# Altium schematic sheet [14] - FPGA POWER.SchDoc("[14] - FPGA POWER")
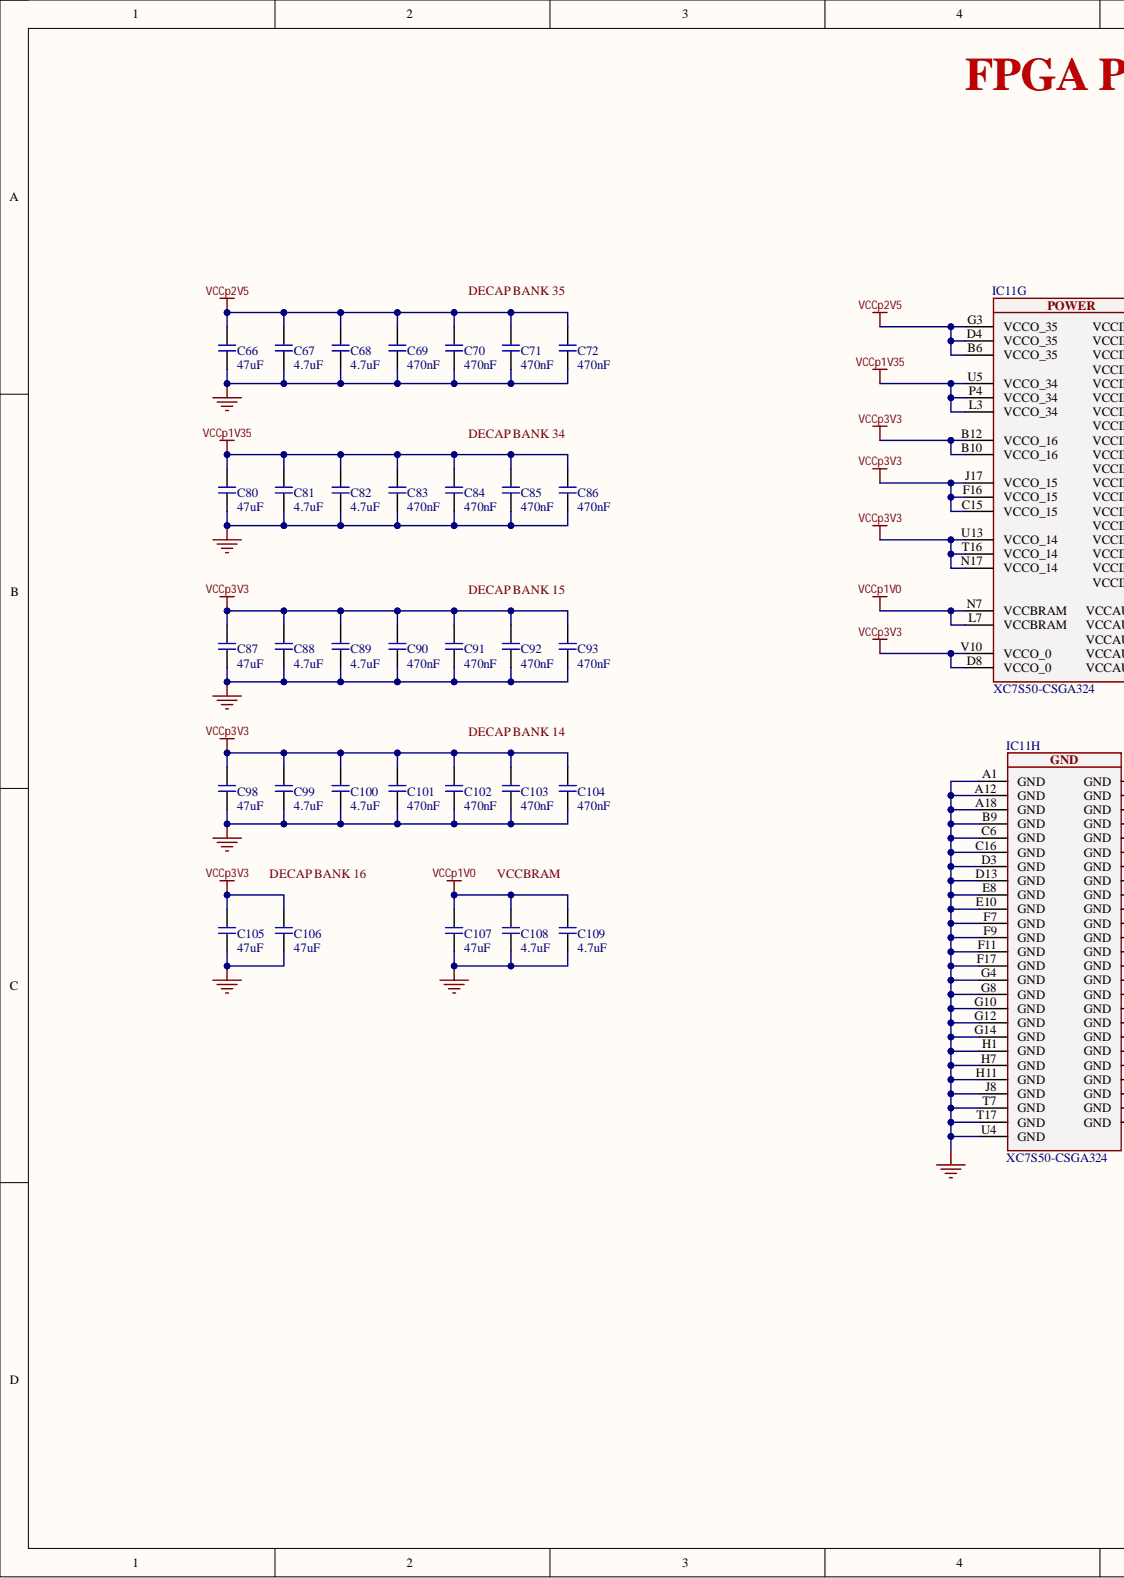
[diagram p0: [14] - FPGA POWER.SchDoc, left]
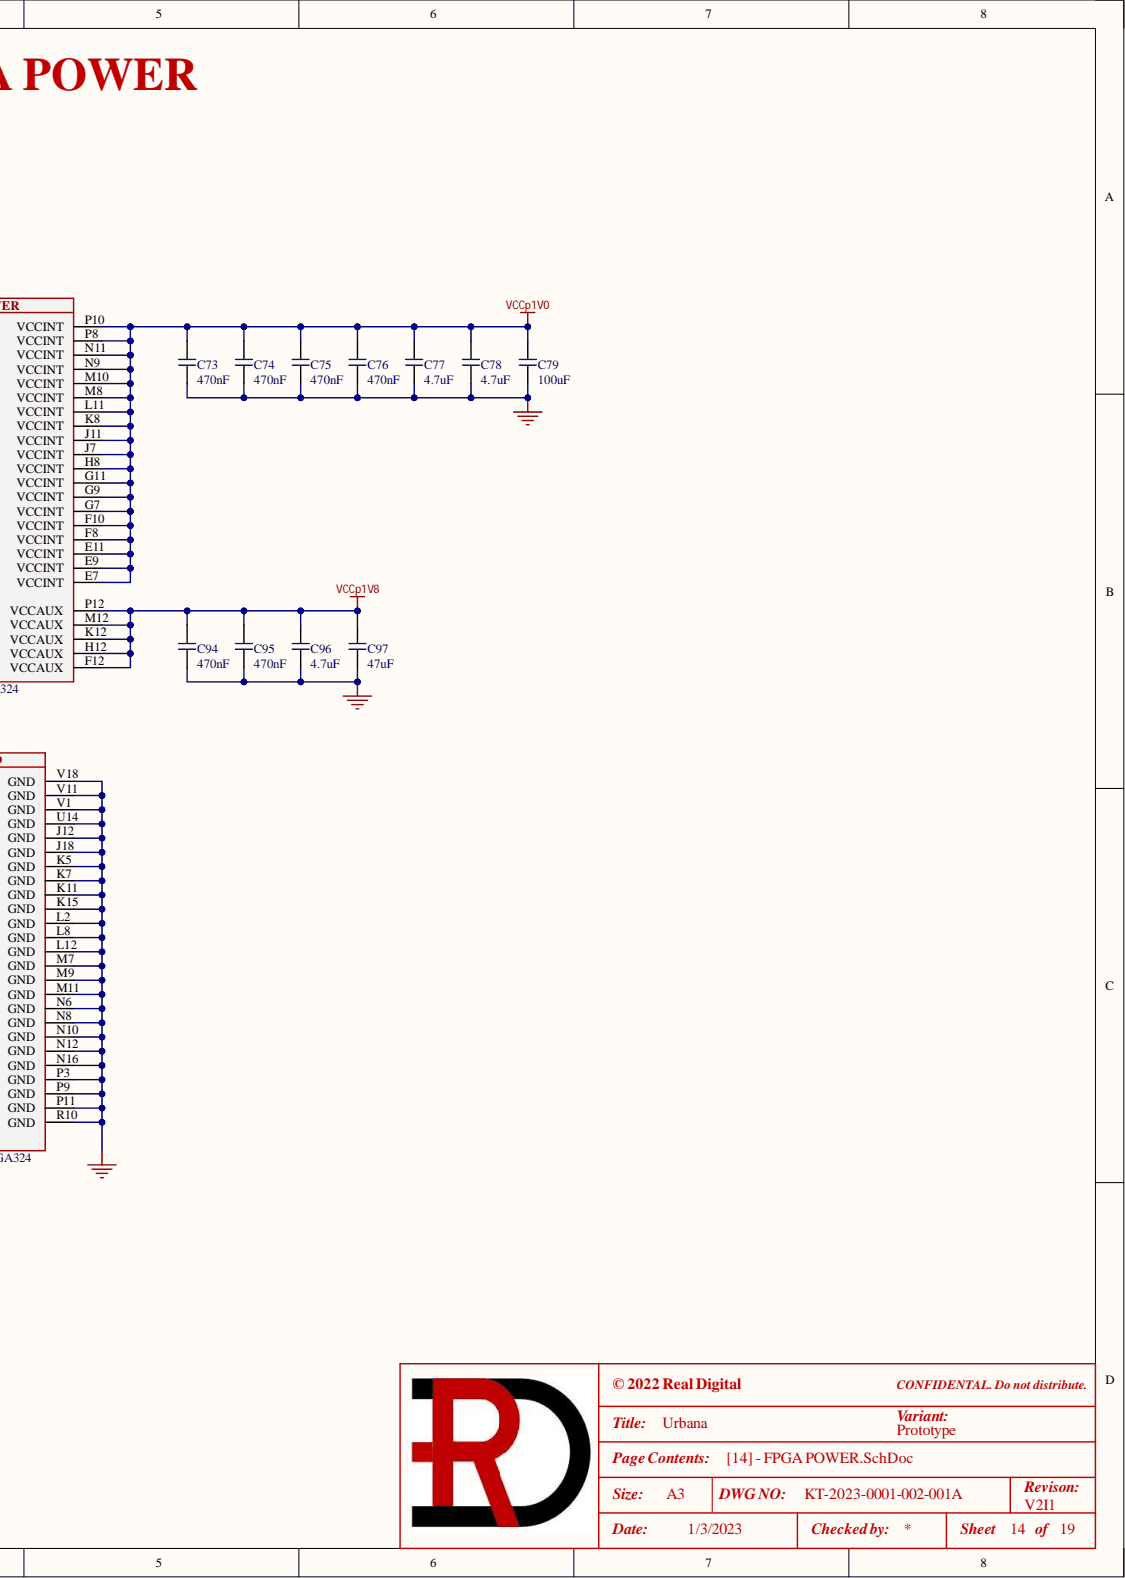
[diagram p1: [14] - FPGA POWER.SchDoc, right]

## Parts
C66  47uF  [C1210]
C67  4.7uF  [C0805]
C68  4.7uF  [C0805]
C69  470nF  [C0603]
C70  470nF  [C0603]
C71  470nF  [C0603]
C72  470nF  [C0603]
C73  470nF  [C0603]
C74  470nF  [C0603]
C75  470nF  [C0603]
C76  470nF  [C0603]
C77  4.7uF  [C0805]
C78  4.7uF  [C0805]
C79  100uF  [C1210]
C80  47uF  [C1210]
C81  4.7uF  [C0805]
C82  4.7uF  [C0805]
C83  470nF  [C0603]
C84  470nF  [C0603]
C85  470nF  [C0603]
C86  470nF  [C0603]
C87  47uF  [C1210]
C88  4.7uF  [C0805]
C89  4.7uF  [C0805]
C90  470nF  [C0603]
C91  470nF  [C0603]
C92  470nF  [C0603]
C93  470nF  [C0603]
C94  470nF  [C0603]
C95  470nF  [C0603]
C96  4.7uF  [C0805]
C97  47uF  [C1210]
C98  47uF  [C1210]
C99  4.7uF  [C0805]
C100  4.7uF  [C0805]
C101  470nF  [C0603]
C102  470nF  [C0603]
C103  470nF  [C0603]
C104  470nF  [C0603]
C105  47uF  [C1210]
C106  47uF  [C1210]
C107  47uF  [C1210]
C108  4.7uF  [C0805]
C109  4.7uF  [C0805]
IC11  XC7S50-CSGA324  [CSG324]  (parts G,H)

## Nets
GND: C66.1 C67.1 C68.1 C69.1 C70.1 C71.1 C72.1 C73.1 C74.1 C75.1 C76.1 C77.1 C78.1 C79.1 C80.1 C81.1 C82.1 C83.1 C84.1 C85.1 C86.1 C87.1 C88.1 C89.1 C90.1 C91.1 C92.1 C93.1 C94.1 C95.1 C96.1 C97.1 C98.1 C99.1 C100.1 C101.1 C102.1 C103.1 C104.1 C105.1 C106.1 C107.1 C108.1 C109.1 IC11.A1(GND) IC11.A12(GND) IC11.A18(GND) IC11.B9(GND) IC11.C6(GND) IC11.C16(GND) IC11.D3(GND) IC11.D13(GND) IC11.E8(GND) IC11.E10(GND) IC11.F7(GND) IC11.F9(GND) IC11.F11(GND) IC11.F17(GND) IC11.G4(GND) IC11.G8(GND) IC11.G10(GND) IC11.G12(GND) IC11.G14(GND) IC11.H1(GND) IC11.H7(GND) IC11.H11(GND) IC11.J8(GND) IC11.J12(GND) IC11.J18(GND) IC11.K5(GND) IC11.K7(GND) IC11.K11(GND) IC11.K15(GND) IC11.L2(GND) IC11.L8(GND) IC11.L12(GND) IC11.M7(GND) IC11.M9(GND) IC11.M11(GND) IC11.N6(GND) IC11.N8(GND) IC11.N10(GND) IC11.N12(GND) IC11.N16(GND) IC11.P3(GND) IC11.P9(GND) IC11.P11(GND) IC11.R10(GND) IC11.T7(GND) IC11.T17(GND) IC11.U4(GND) IC11.U14(GND) IC11.V1(GND) IC11.V11(GND) IC11.V18(GND)
VCCp1V0: C73.2 C74.2 C75.2 C76.2 C77.2 C78.2 C79.2 C107.2 C108.2 C109.2 IC11.E7(VCCINT) IC11.E9(VCCINT) IC11.E11(VCCINT) IC11.F8(VCCINT) IC11.F10(VCCINT) IC11.G7(VCCINT) IC11.G9(VCCINT) IC11.G11(VCCINT) IC11.H8(VCCINT) IC11.J7(VCCINT) IC11.J11(VCCINT) IC11.K8(VCCINT) IC11.L7(VCCBRAM) IC11.L11(VCCINT) IC11.M8(VCCINT) IC11.M10(VCCINT) IC11.N7(VCCBRAM) IC11.N9(VCCINT) IC11.N11(VCCINT) IC11.P8(VCCINT) IC11.P10(VCCINT)
VCCp1V8: C94.2 C95.2 C96.2 C97.2 IC11.F12(VCCAUX) IC11.H12(VCCAUX) IC11.K12(VCCAUX) IC11.M12(VCCAUX) IC11.P12(VCCAUX)
VCCp1V35: C80.2 C81.2 C82.2 C83.2 C84.2 C85.2 C86.2 IC11.L3(VCCO_34) IC11.P4(VCCO_34) IC11.U5(VCCO_34)
VCCp2V5: C66.2 C67.2 C68.2 C69.2 C70.2 C71.2 C72.2 IC11.B6(VCCO_35) IC11.D4(VCCO_35) IC11.G3(VCCO_35)
VCCp3V3: C87.2 C88.2 C89.2 C90.2 C91.2 C92.2 C93.2 C98.2 C99.2 C100.2 C101.2 C102.2 C103.2 C104.2 C105.2 C106.2 IC11.B10(VCCO_16) IC11.B12(VCCO_16) IC11.C15(VCCO_15) IC11.D8(VCCO_0) IC11.F16(VCCO_15) IC11.J17(VCCO_15) IC11.N17(VCCO_14) IC11.T16(VCCO_14) IC11.U13(VCCO_14) IC11.V10(VCCO_0)

## Library data of the parts
C66 C80 C87 C97 C98 C105-C107 = GRM32EC81C476KE15K: CAP CER 47uF 16V X6S 1210; Manufacturer 1: Murata Electronics; Manufacturer 2 Part Number: GRM32EC81C476KE15L; .Checked_PCB: AC; .Creator: RV; LoadStatus: Load; Tolerance: 10%; Category: Capacitors; Component Kind: Standard; Library Name: KT-RealDigital.IntLib; Manufacturer 1 Part Number: GRM32EC81C476KE15K; Manufacturer 2: Murata Electronics; Temperature Coefficient: X6S; Voltage - Rated: 16V; .Checked_SCH: AC
C67 C68 C77 C78 C81 C82 C88 C89 C96 C99 C100 C108 C109 = GRM21BR71C475KE51L: CAP CER 4.7uF 16V X7R 0805; Manufacturer 1: Murata Electronics; .Checked_PCB: AC; .Creator: RV; C P/N: 111-0609; LoadStatus: Load; Tolerance: 10%; Category: Capacitors; Component Kind: Standard; Library Name: KT-RealDigital.IntLib; Manufacturer 1 Part Number: GRM21BR71C475KE51L; Temperature Coefficient: X7R; Voltage - Rated: 16V; .Checked_SCH: AC
C69-C76 C83-C86 C90-C95 C101-C104 = GRM188R71E474KA12D: CAP CER 0.47uF 25V X7R 0603; Manufacturer 1: Murata Electronics; .Checked_PCB: AC; .Creator: RV; C P/N: RD-111-0008; LoadStatus: Load; Tolerance: 10%; Category: Capacitors; Component Kind: Standard; Library Name: KT-RealDigital.IntLib; Manufacturer 1 Part Number: GRM188R71E474KA12D; Temperature Coefficient: X7R; Voltage - Rated: 25V; .Checked_SCH: AC
C79 = GRM32ER61A107ME20L: CAP CER 100uF 10V X5R 1210; Manufacturer 1: Murata Electronics; .Checked_PCB: AC; .Creator: RV; C P/N: RD-111-0004; LoadStatus: Load; Tolerance: 20%; Category: Capacitors; Component Kind: Standard; Library Name: KT-RealDigital.IntLib; Manufacturer 1 Part Number: GRM32ER61A107ME20L; Temperature Coefficient: X5R; Voltage - Rated: 10V; .Checked_SCH: AC
IC11 = XC7S50-CSGA324: FPGA 210 I/O 324CSBGA; Manufacturer 1: Xilinx; .Checked_PCB: RV; C P/N: RD-126-0003-CD; LoadStatus: Load; Category: IC; Component Kind: Standard; Library Name: KT-RealDigital.IntLib; Manufacturer 1 Part Number: XC7S50-CSGA324; .Checked_SCH: RV; .Creator: RV
